# Altium schematic sheet Outlet Plug Smart.SchDoc
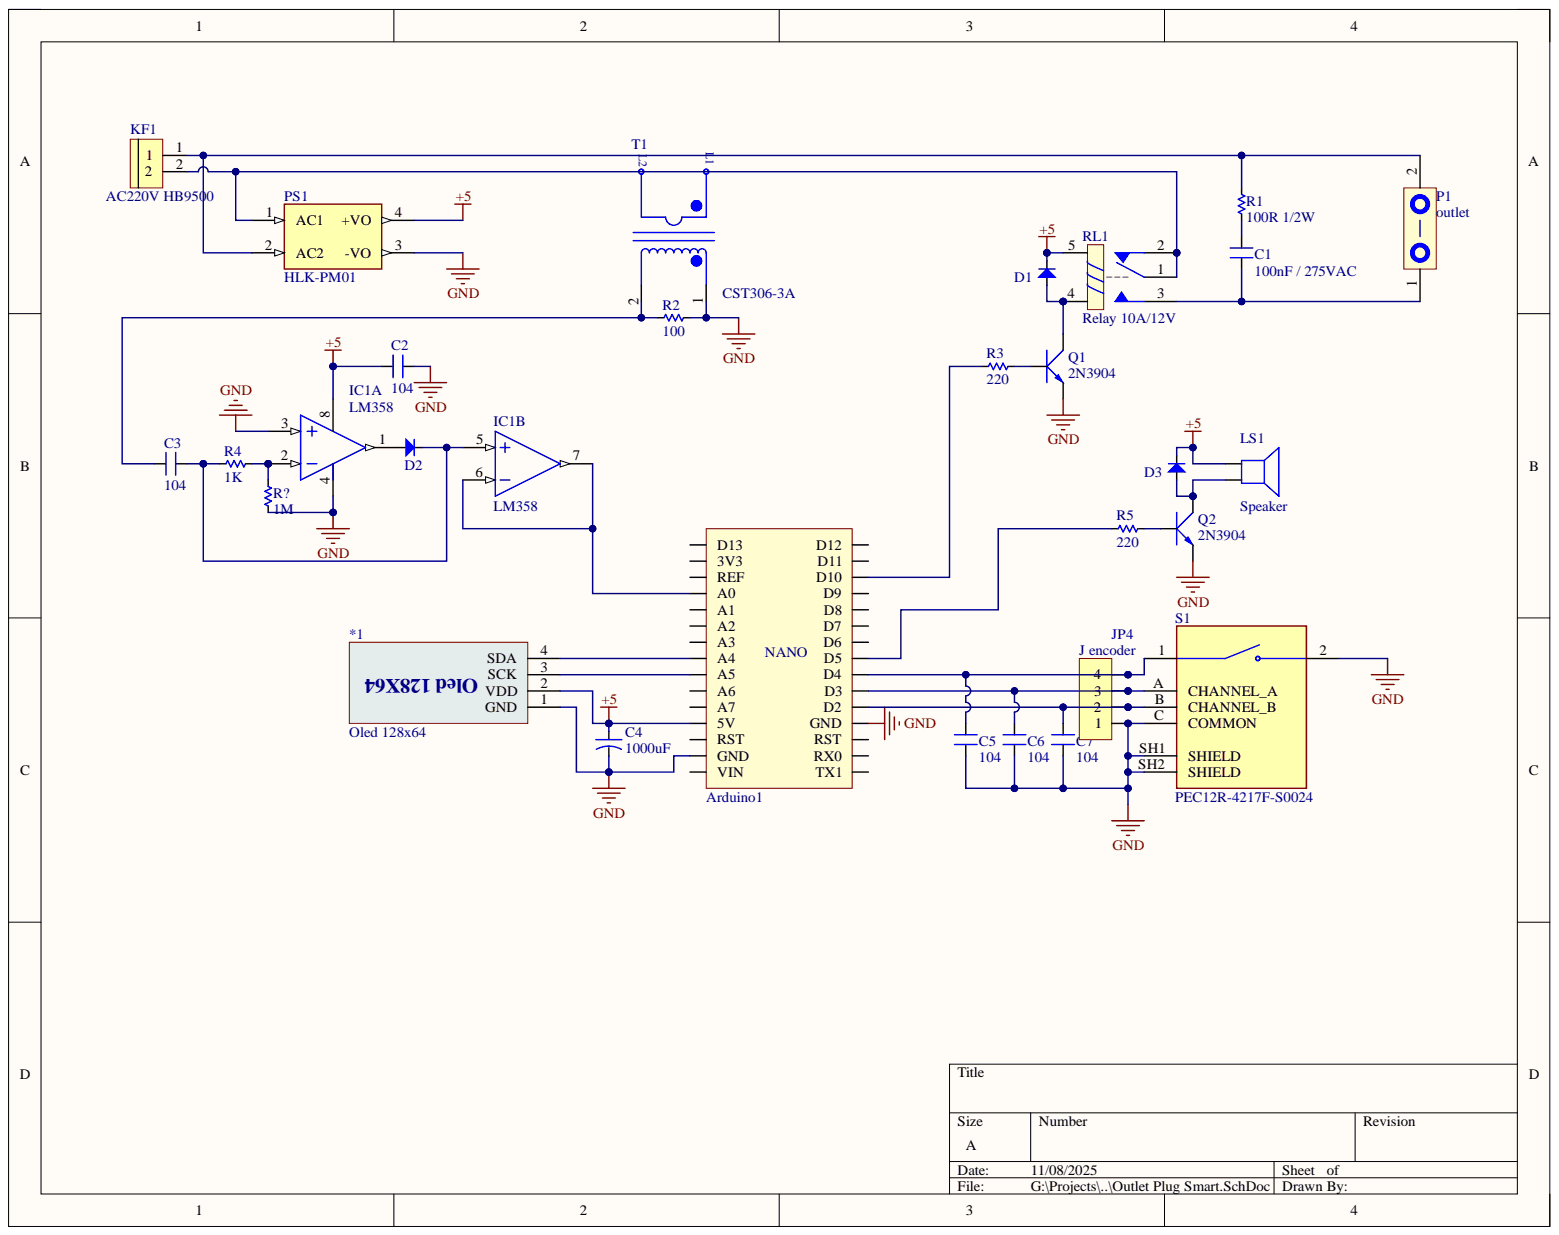
[diagram p0: Outlet Plug Smart.SchDoc]

## Parts
*1  Oled 128x64  [Oled 128x64]
Arduino1  Arduino Nano  [Arduino Nano]  (value not drawn)
C1  100nF / 275VAC  [C-SE-7,5x10x9x5x4]
C2  104  [CN2-O]
C3  104  [CN2-O]
C4  1000uF  [CA-ALU-2.54]
C5  104  [CN2-O]
C6  104  [CN2-O]
C7  104  [CN2-O]
D1  Diode  [DIO-1A]  (value not drawn)
D2  Diode  [DIO-1A]  (value not drawn)
D3  Diode  [DIO-1A]  (value not drawn)
IC1  LM358  [DIP8]  (parts A,B)
J_encoder  JP4  [JP4-C1T]
KF1  AC220V HB9500  [CON 2 HB9500]
LS1  Speaker  [SPEAKER]
P1  outlet  [outlet]
PS1  HLK-PM01  [CONV_HLK-PM01]
Q1  2N3904  [TO92-123]
Q2  2N3904  [TO92-123]
R1  100R 1/2W  [RES-1/2W]
R2  100  [RES-1/4W]
R3  220  [RES-1/4W]
R4  1K  [RES-1/4W]
R5  220  [RES-1/4W]
R?  1M  [RES-1/4W]
RL1  Relay 10A/12V  [RELAY_SLA-5VDC-SL-A]
S1  PEC12R-4217F-S0024  [XDCR_PEC12R-4217F-S0024]
T1  CST306-3A  [XFMR_CST306-3A]

## Nets
+5: *1.2(VDD) Arduino1.5V C2.1 C4.1 D1.2 D3.2 IC1.8 LS1.1 PS1.4(+VO) RL1.5
GND: *1.1(GND) Arduino1.GND C2.2 C4.2 C5.1 C6.1 C7.1 IC1.3 IC1.4 J_encoder.1 PS1.3(-VO) Q1.1 Q2.1 R2.1 R?.2 S1.2 S1.C(COMMON) S1.SH1(SHIELD) S1.SH2(SHIELD) T1.1
Net*1_3: *1.3(SCK) Arduino1.A5
Net*1_4: *1.4(SDA) Arduino1.A4
NetArduino1_A0: Arduino1.A0 IC1.6 IC1.7
NetArduino1_D2: Arduino1.D2 C7.2 J_encoder.2 S1.B(CHANNEL_B)
NetArduino1_D3: Arduino1.D3 C6.2 J_encoder.3 S1.A(CHANNEL_A)
NetArduino1_D4: Arduino1.D4 C5.2 J_encoder.4 S1.1
NetArduino1_D5: Arduino1.D5 R5.2
NetArduino1_D10: Arduino1.D10 R3.2
NetC1_1: C1.1 P1.1 RL1.3
NetC1_2: C1.2 R1.2
NetC3_1: C3.1 R2.2 T1.2
NetC3_2: C3.2 D2.2 IC1.5 R4.2
NetD1_1: D1.1 Q1.3 RL1.4
NetD2_1: D2.1 IC1.1
NetD3_1: D3.1 LS1.2 Q2.3
NetIC1_2: IC1.2 R4.1 R?.1
NetKF1_1: KF1.1 P1.2 PS1.2(AC2) R1.1
NetKF1_2: KF1.2 PS1.1(AC1) RL1.1 RL1.2
NetQ1_2: Q1.2 R3.1
NetQ2_2: Q2.2 R5.1

Not connected: Arduino1 3V3 A1 A2 A3 A6 A7 D6 D7 D8 D9 D11 D12 D13 REF RST RX0 TX1 VIN

## Library data of the parts
Arduino1 = Arduino Nano: Value: Cau 1A; Component Kind: Standard; Description: Arduino NANO; Library Name: LIBRARY.IntLib
C1 = CN-SEMI-DIP: Capacitor (Semiconductor SIM Model); Value: 104; Component Kind: Standard; LatestRevisionDate: 17-Jul-2002; LatestRevisionNote: Re-released for DXP Platform.; Library Name: LIBRARY.IntLib; PackageReference: C1206; Publisher: Altium Limited
C2 C3 C5-C7 = CN: Value: 33pF; Component Kind: Standard; Library Name: LIBRARY.IntLib
C4 = CN-ALU-DIP: Capacitor (Semiconductor SIM Model); Value: 104; Component Kind: Standard; LatestRevisionDate: 17-Jul-2002; LatestRevisionNote: Re-released for DXP Platform.; Library Name: LIBRARY.IntLib; PackageReference: C1206; Publisher: Altium Limited
D1-D3 = Diode: Default Diode; Code - JEDEC: DO-214-AB; Value: 1N5007; Component Kind: Standard; Library Name: LIBRARY.IntLib; Published: 8-Jun-2000; Revision: July-2002: Re-released for DXP Platform.; Publisher: Altium Limited
IC1 = LM358: Low-Power Dual Operational Amplifier; Component Kind: Standard; Library Name: LIBRARY.IntLib; Value: LM358
J_encoder = JP4: WR-PHD 2.54 mm Angled Socket Header; Manufacturer: W¨¹rth Elektronik eiSos GmbH; partNo: 613004143121; ComponentLink1 Description: Manufacturer; ComponentLink2 Description: Datasheet; NoPins: 04; Component Kind: Standard; ComponentLink1URL: http://www.we-online.com; ComponentLink2URL: http://katalog.we-online.de/em/datasheet/6130xx143121.pdf; Created Date: 29 March 2013; Library Name: LIBRARY.IntLib; Created by: Karrer Zheng
KF1 = CON 2 HB9500: Cắm; Don_Vi: Con; Ma_Hang: MH002054; Ten_Linh_Kien: CON 2 HB9500-2P 9.5MM; Chung_Loai: Cắm; Component Kind: Standard; Library Name: Connector.SchLib
LS1 = Speaker: Loudspeaker; Component Kind: Standard; LatestRevisionDate: 17-Jul-2002; LatestRevisionNote: Re-released for DXP Platform.; Library Name: LIBRARY.IntLib; PackageReference: PIN2; Published: 24-Mar-1999; Publisher: Altium Limited
PS1 = HLK-PM01: MF: {'manufacturer': u'Hi-link'}; Availability: Unavailable; Component Kind: Standard; Library Name: SnapEDA_Library.IntLib; MP: HLK-PM01; Package: None; Price: None
Q1 Q2 = 2N904: NPN 600mW, ECB; Value: C1815; Component Kind: Standard; Library Name: LIBRARY.IntLib
R1-R5 R? = Res: Manufacturer: Generic Components; Class: Passive; SubClass: R; Component Kind: Standard; Description: Res; Library Name: LIBRARY.IntLib; Published: 08/06/2000; Publisher: Altium Hobart Technology Centre
RL1 = Relay 5: Relay SPDT,10A - 12VDC 5 Pin; Value: Relay SPDT, 10A, 12V; Component Kind: Standard; Library Name: Library.SchLib
S1 = PEC12R-4217F-S0024: MF: {'manufacturer': u'Bourns'}; Availability: Unavailable; Component Kind: Standard; Library Name: SnapEDA_Library.IntLib; MP: PEC12R-4217F-S0024; Package: None; Price: None
T1 = CST306-3A: MF: {'manufacturer': u'Triad Magnetics'}; Availability: Unavailable; Component Kind: Standard; Library Name: CST306-3A.SchLib; MP: CST306-3A; Package: None; Price: None
*1 = Oled 128x64: Component Kind: Standard; Library Name: MY LIBRARY.SchLib
P1 = outlet: Component Kind: Standard; Library Name: MY LIBRARY.SchLib
